# Altium schematic sheet LED.SchDoc(LED)
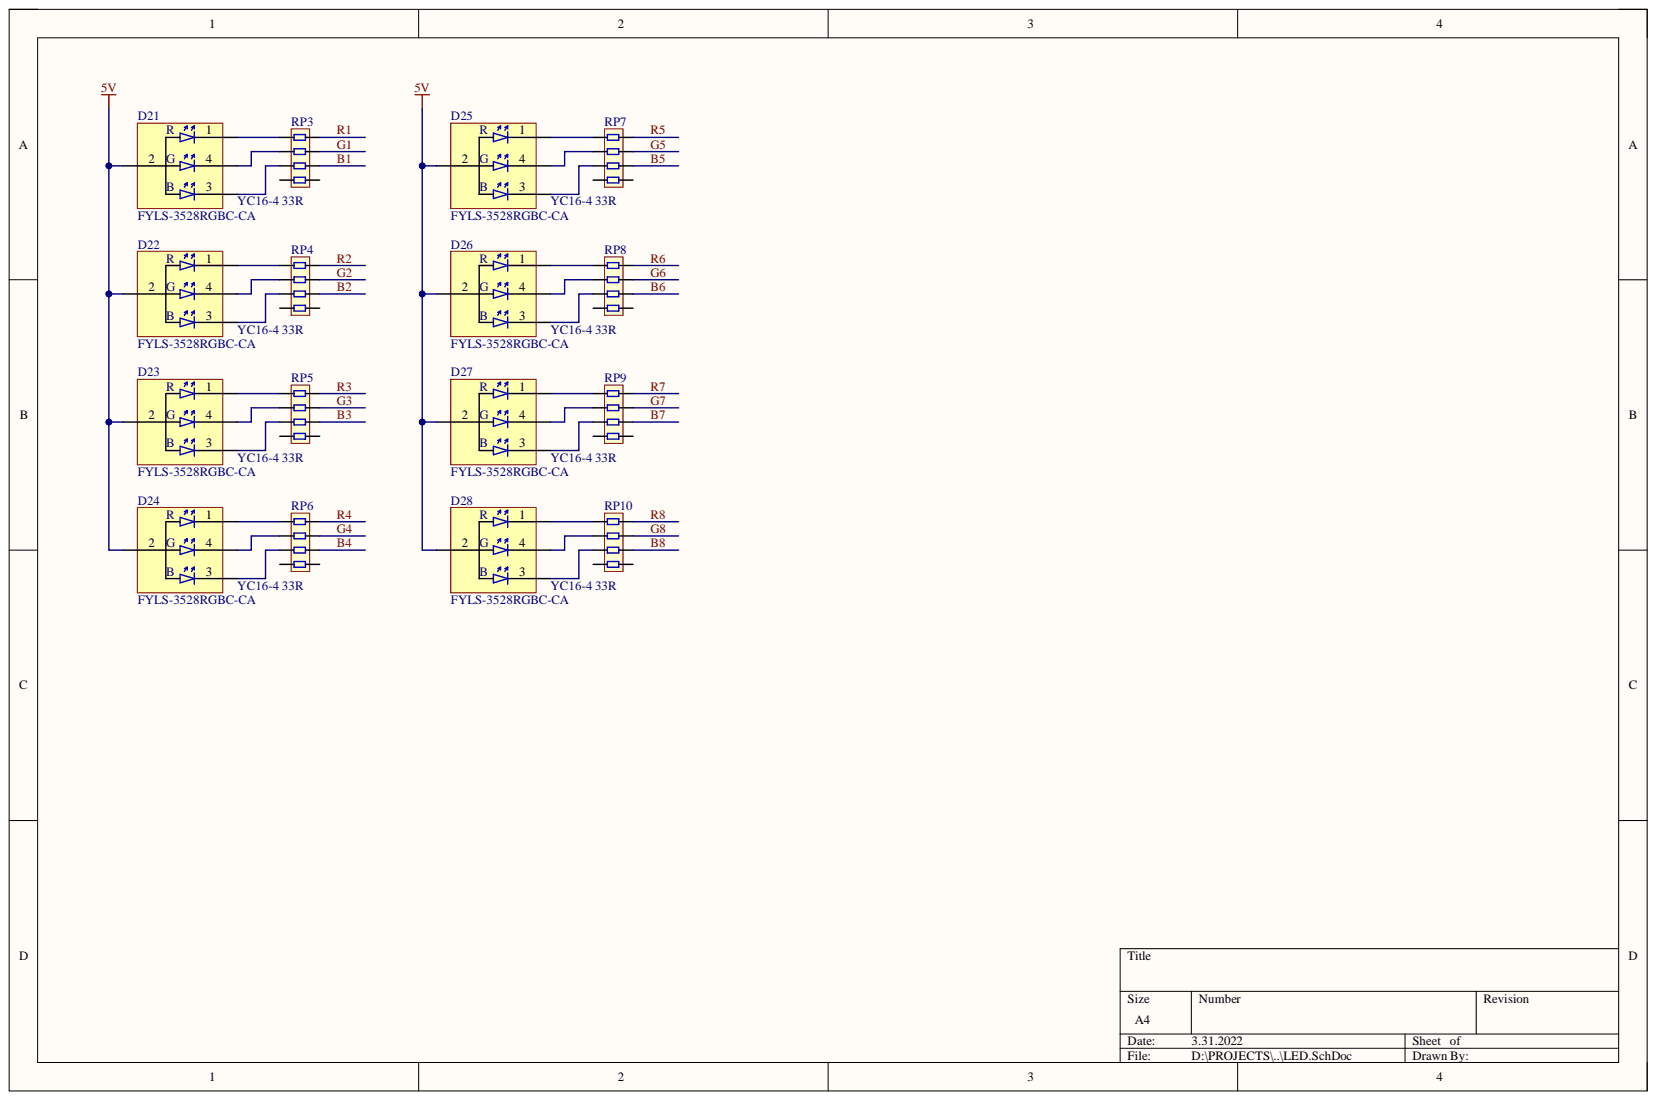
[diagram p0: LED.SchDoc]

## Parts
D21  FYLS-3528RGBC-CA  [CHIP_LED_3528]
D22  FYLS-3528RGBC-CA  [CHIP_LED_3528]
D23  FYLS-3528RGBC-CA  [CHIP_LED_3528]
D24  FYLS-3528RGBC-CA  [CHIP_LED_3528]
D25  FYLS-3528RGBC-CA  [CHIP_LED_3528]
D26  FYLS-3528RGBC-CA  [CHIP_LED_3528]
D27  FYLS-3528RGBC-CA  [CHIP_LED_3528]
D28  FYLS-3528RGBC-CA  [CHIP_LED_3528]
RP3  YC16-4 33R  [YC16-4]
RP4  YC16-4 33R  [YC16-4]
RP5  YC16-4 33R  [YC16-4]
RP6  YC16-4 33R  [YC16-4]
RP7  YC16-4 33R  [YC16-4]
RP8  YC16-4 33R  [YC16-4]
RP9  YC16-4 33R  [YC16-4]
RP10  YC16-4 33R  [YC16-4]

## Nets
5V: D21.2 D22.2 D23.2 D24.2 D25.2 D26.2 D27.2 D28.2
B1: RP3.7
B2: RP4.7
B3: RP5.7
B4: RP6.7
B5: RP7.7
B6: RP8.7
B7: RP9.7
B8: RP10.7
G1: RP3.6
G2: RP4.6
G3: RP5.6
G4: RP6.6
G5: RP7.6
G6: RP8.6
G7: RP9.6
G8: RP10.6
R1: RP3.5
R2: RP4.5
R3: RP5.5
R4: RP6.5
R5: RP7.5
R6: RP8.5
R7: RP9.5
R8: RP10.5
NetD21_1: D21.1 RP3.4
NetD21_3: D21.3 RP3.2
NetD21_4: D21.4 RP3.3
NetD22_1: D22.1 RP4.4
NetD22_3: D22.3(B) RP4.2
NetD22_4: D22.4 RP4.3
NetD23_1: D23.1 RP5.4
NetD23_3: D23.3 RP5.2
NetD23_4: D23.4 RP5.3
NetD24_1: D24.1 RP6.4
NetD24_3: D24.3 RP6.2
NetD24_4: D24.4 RP6.3
NetD25_1: D25.1 RP7.4
NetD25_3: D25.3 RP7.2
NetD25_4: D25.4 RP7.3
NetD26_1: D26.1 RP8.4
NetD26_3: D26.3(B) RP8.2
NetD26_4: D26.4 RP8.3
NetD27_1: D27.1 RP9.4
NetD27_3: D27.3 RP9.2
NetD27_4: D27.4 RP9.3
NetD28_1: D28.1 RP10.4
NetD28_3: D28.3 RP10.2
NetD28_4: D28.4 RP10.3

Not connected: RP3 1 8; RP4 1 8; RP5 1 8; RP6 1 8; RP7 1 8; RP8 1 8; RP9 1 8; RP10 1 8

## Library data of the parts
RP3-RP10 = YC16-4: Component Kind: Standard; Library Name: Popular.SchLib
D21-D28 = FYLS-3528RGBC-CA: Component Kind: Standard; Library Name: Popular.SchLib
